# Altium schematic sheet Low_Voltage.SchDoc(Low_Voltage)
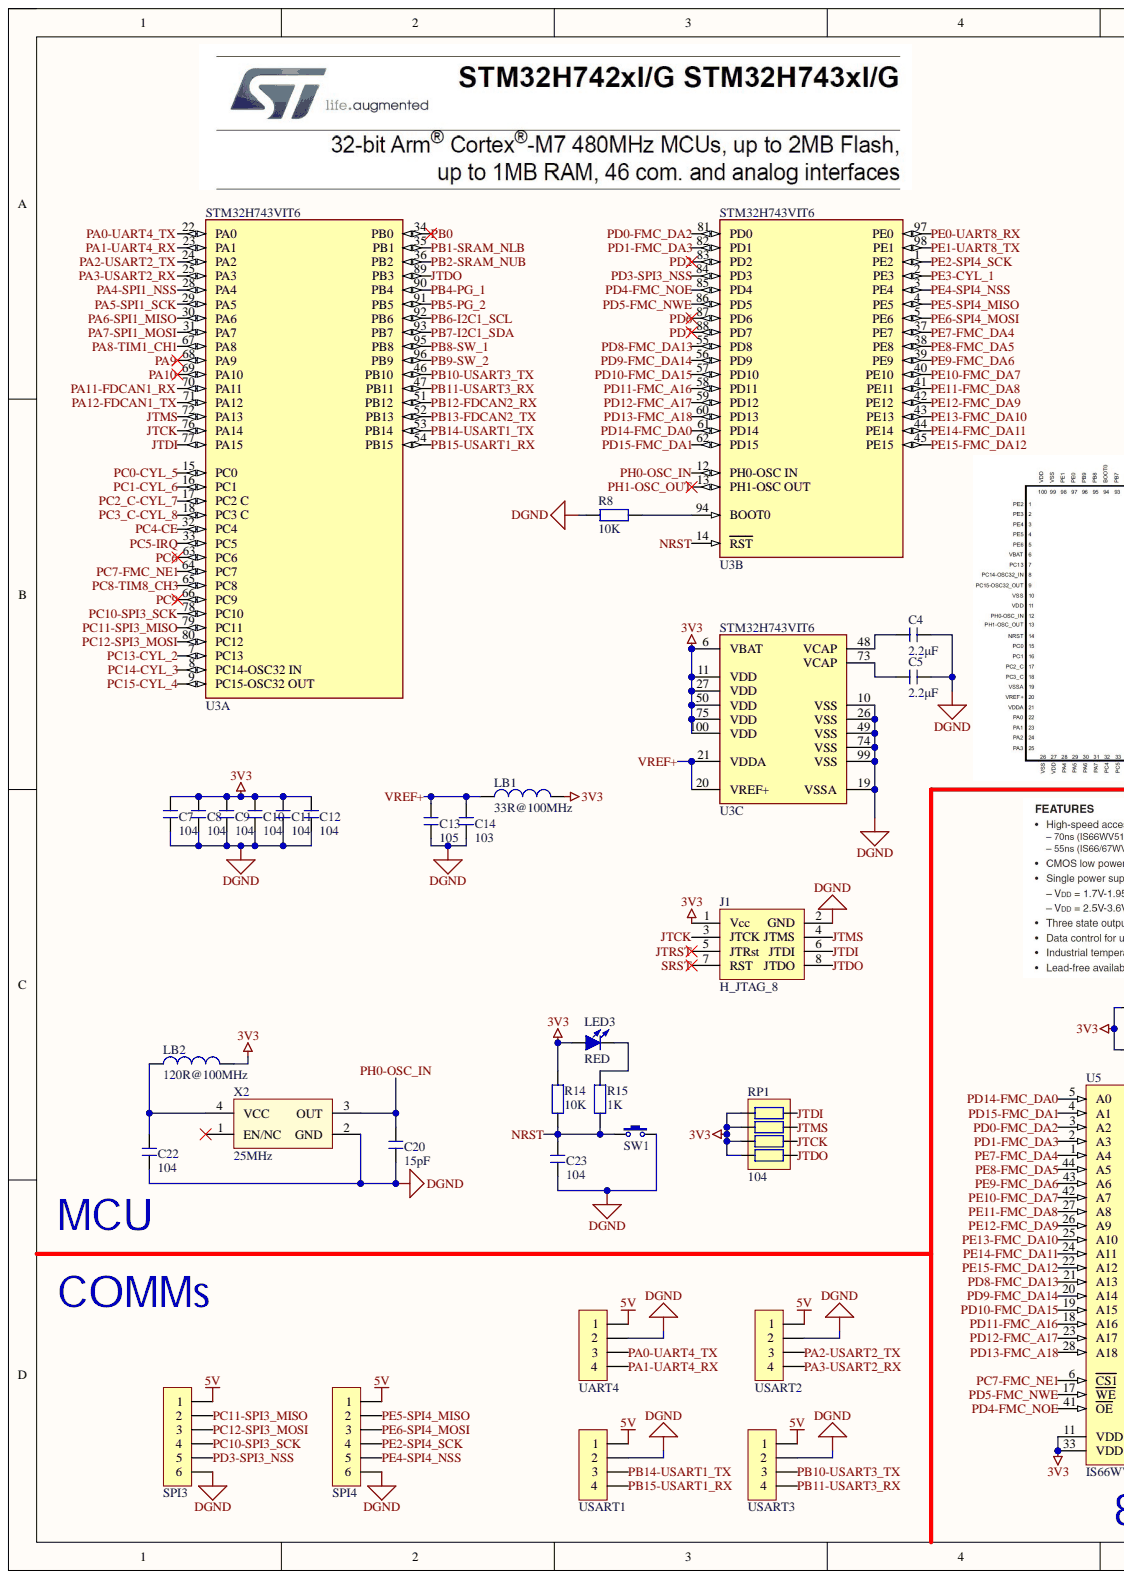
[diagram p0: Low_Voltage.SchDoc, left]
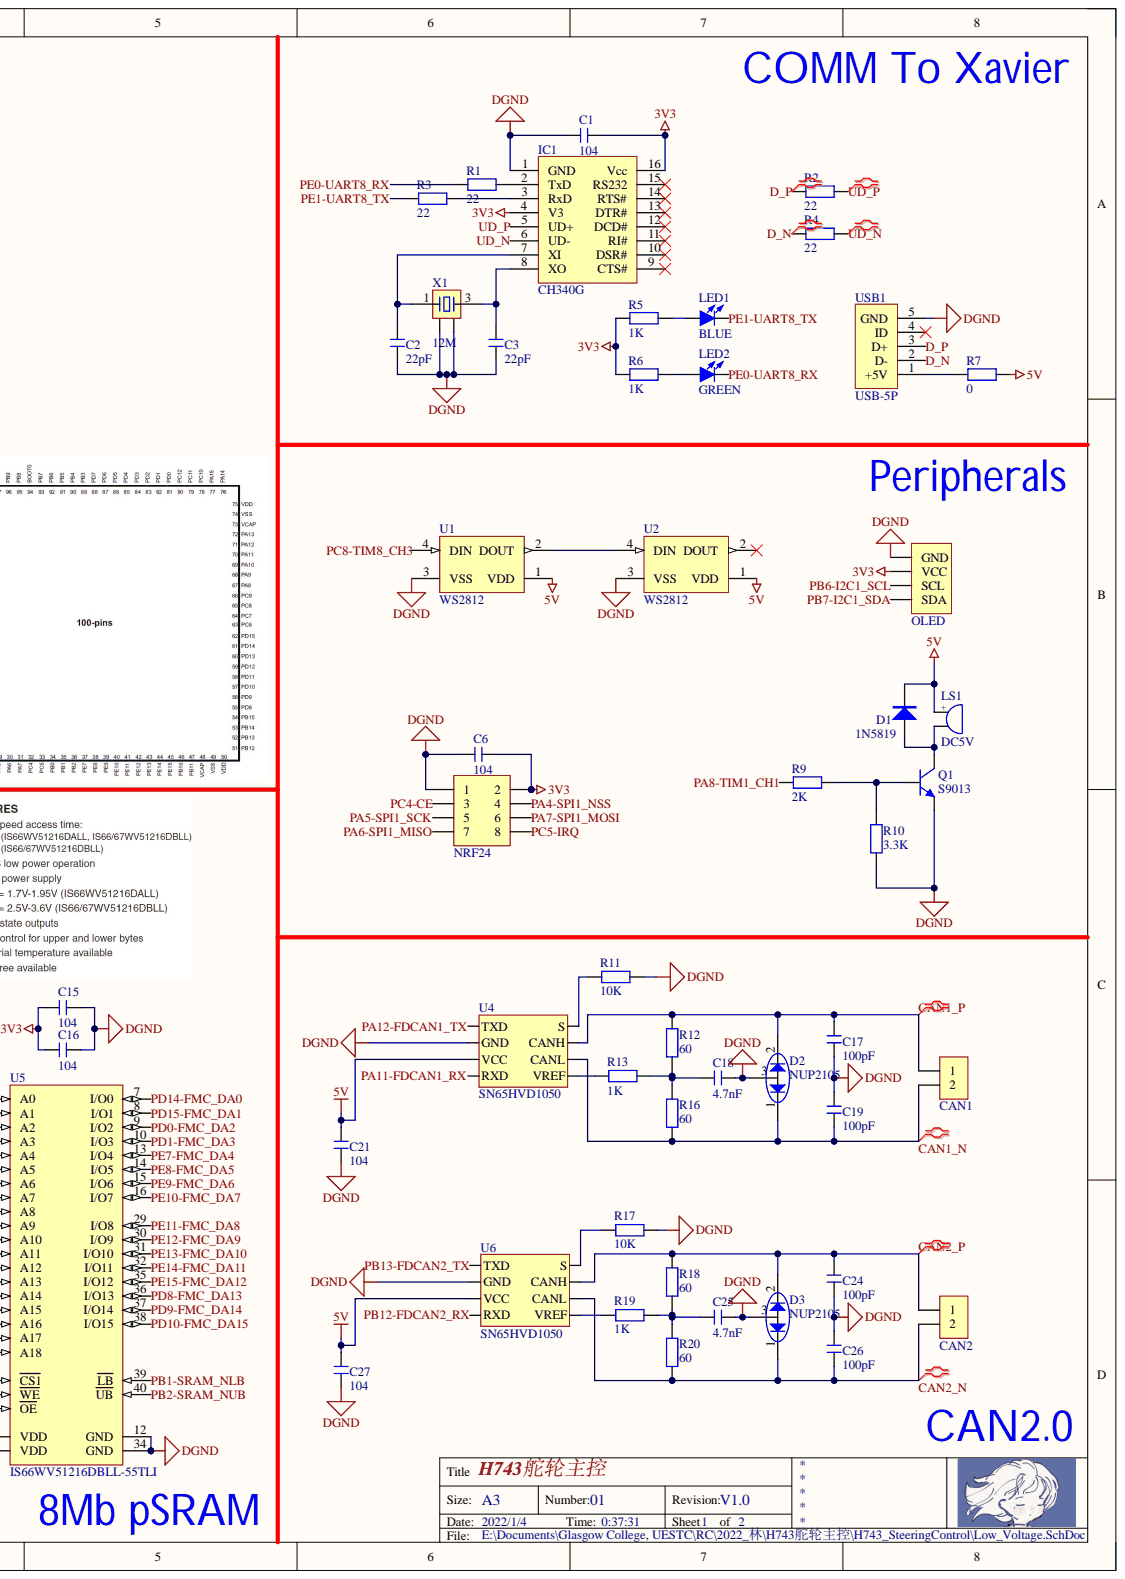
[diagram p1: Low_Voltage.SchDoc, right]

## Parts
C1  104  [C 0603_L]
C2  22pF  [C 0603_L]
C3  22pF  [C 0603_L]
C4  2.2μF  [C 0603_L]
C5  2.2μF  [C 0603_L]
C6  104  [C 0603_L]
C7  104  [C 0603_L]
C8  104  [C 0603_L]
C9  104  [C 0603_L]
C10  104  [C 0603_L]
C11  104  [C 0603_L]
C12  104  [C 0603_L]
C13  105  [C 0603_L]
C14  103  [C 0603_L]
C15  104  [C 0603_L]
C16  104  [C 0603_L]
C17  100pF  [C 0603_L]
C18  4.7nF  [C 0603_L]
C19  100pF  [C 0603_L]
C20  15pF  [C 0603_L]
C21  104  [C 0603_L]
C22  104  [C 0603_L]
C23  104  [C 0603_L]
C24  100pF  [C 0603_L]
C25  4.7nF  [C 0603_L]
C26  100pF  [C 0603_L]
C27  104  [C 0603_L]
CAN1  HDR-1X2  [XH2.54-LS-2P]  (value not drawn)
CAN2  HDR-1X2  [XH2.54-LS-2P]  (value not drawn)
D1  1N5819  [SOD-123]
D2  NUP2105  [SOT23-3L]
D3  NUP2105  [SOT23-3L]
IC1  CH340G  [SOP16_N]
J1  H_JTAG_8  [IDC2.54-LI-2x4P]
LB1  33R@100MHz  [FB 0603_L]
LB2  120R@100MHz  [FB 0603_L]
LED1  BLUE  [LED 0603B]
LED2  GREEN  [LED 0603G]
LED3  RED  [LED 0603R]
LS1  DC5V  [BEEP 6.5x12x6]
NRF24  E01C-ML01D  [HDR2.54-LI-2x4P]  (value not drawn)
OLED  HDR-1X4  [OLED_0.96寸-LI-4P]  (value not drawn)
Q1  S9013  [SOT23-3L]
R1  22  [R 0603_L]
R2  22  [R 0603_L]
R3  22  [R 0603_L]
R4  22  [R 0603_L]
R5  1K  [R 0603_L]
R6  1K  [R 0603_L]
R7  0  [R 0603_L]
R8  10K  [R 0603_L]
R9  2K  [R 0603_L]
R10  3.3K  [R 0603_L]
R11  10K  [R 0603_L]
R12  60  [R 0603_L]
R13  1K  [R 0603_L]
R14  10K  [R 0603_L]
R15  1K  [R 0603_L]
R16  60  [R 0603_L]
R17  10K  [R 0603_L]
R18  60  [R 0603_L]
R19  1K  [R 0603_L]
R20  60  [R 0603_L]
RP1  104  [RCA-8P4R-0603]
SPI3  HDR-1X6  [XH2.54-LS-6P]  (value not drawn)
SPI4  HDR-1X6  [XH2.54-LS-6P]  (value not drawn)
SW1  6x6x5  [TS 2PIN 6x6x4.8]  (value not drawn)
U1  WS2812  [WS2812B_B]
U2  WS2812  [WS2812B_B]
U3  STM32H743VIT6  [LQFP100 14x14_N]  (parts A,B,C)
U4  SN65HVD1050  [SOP8_L]
U5  IS66WV51216DBLL-55TLI  [ISSI-TSOP-2-44_N]
U6  SN65HVD1050  [SOP8_L]
UART4  HDR-1X4  [XH2.54-LS-4P]  (value not drawn)
USART1  HDR-1X4  [XH2.54-LS-4P]  (value not drawn)
USART2  HDR-1X4  [XH2.54-LS-4P]  (value not drawn)
USART3  HDR-1X4  [XH2.54-LS-4P]  (value not drawn)
USB1  USB-5P  [USB-MICRO_C]
X1  12M  [OSC 3225-4P]
X2  25MHz  [OSC 3225-4P]

## Nets
3V3: C1.1 C6.1 C7.2 C8.2 C9.2 C10.2 C11.2 C12.2 C15.2 C16.2 IC1.4(V3) IC1.16(Vcc) J1.1(Vcc) LB1.2 LB2.2 LED3.1 NRF24.2 OLED.2(VCC) R5.1 R6.1 R14.2 RP1.5 RP1.6 RP1.7 RP1.8 U3.6(VBAT) U3.11(VDD) U3.27(VDD) U3.50(VDD) U3.75(VDD) U3.100(VDD) U5.11(VDD) U5.33(VDD)
5V: C21.2 C27.2 D1.2 LS1.1 R7.2 SPI3.1 SPI4.1 U1.1(VDD) U2.1(VDD) U4.3(VCC) U6.3(VCC) UART4.1 USART1.1 USART2.1 USART3.1
DGND: C1.2 C2.1 C3.1 C4.2 C5.2 C6.2 C7.1 C8.1 C9.1 C10.1 C11.1 C12.1 C13.1 C14.1 C15.1 C16.1 C17.1 C18.2 C19.2 C20.1 C21.1 C22.1 C23.1 C24.1 C25.2 C26.2 C27.1 D2.3 D3.3 IC1.1(GND) J1.2(GND) NRF24.1 OLED.1(GND) Q1.2 R8.1 R10.1 R11.2 R17.2 SPI3.6 SPI4.6 SW1.2 U1.3(VSS) U2.3(VSS) U3.10(VSS) U3.19(VSSA) U3.26(VSS) U3.49(VSS) U3.74(VSS) U3.99(VSS) U4.2(GND) U5.12(GND) U5.34(GND) U6.2(GND) UART4.2 USART1.2 USART2.2 USART3.2 USB1.5(GND) X1.2 X1.4 X2.2(GND)
CAN1_N: C19.1 CAN1.2 D2.1 R16.1 U4.6(CANL)
CAN1_P: C17.2 CAN1.1 D2.2 R12.2 U4.7(CANH)
CAN2_N: C26.1 CAN2.2 D3.1 R20.1 U6.6(CANL)
CAN2_P: C24.2 CAN2.1 D3.2 R18.2 U6.7(CANH)
D_N: R4.1 USB1.2(D-)
D_P: R2.1 USB1.3(D+)
JTCK: J1.3(JTCK) RP1.2 U3.76(PA14)
JTDI: J1.6(JTDI) RP1.4 U3.77(PA15)
JTDO: J1.8(JTDO) RP1.1 U3.89(PB3)
JTMS: J1.4(JTMS) RP1.3 U3.72(PA13)
JTRST: J1.5(JTRst)
NRST: C23.2 R14.1 R15.1 SW1.1 U3.14(RST)
PA0-UART4_TX: U3.22(PA0) UART4.3
PA1-UART4_RX: U3.23(PA1) UART4.4
PA2-USART2_TX: U3.24(PA2) USART2.3
PA3-USART2_RX: U3.25(PA3) USART2.4
PA4-SPI1_NSS: NRF24.4 U3.28(PA4)
PA5-SPI1_SCK: NRF24.5 U3.29(PA5)
PA6-SPI1_MISO: NRF24.7 U3.30(PA6)
PA7-SPI1_MOSI: NRF24.6 U3.31(PA7)
PA8-TIM1_CH1: R9.1 U3.67(PA8)
PA9: U3.68(PA9)
PA10: U3.69(PA10)
PA11-FDCAN1_RX: U3.70(PA11) U4.4(RXD)
PA12-FDCAN1_TX: U3.71(PA12) U4.1(TXD)
PB0: U3.34(PB0)
PB1-SRAM_NLB: U3.35(PB1) U5.39(LB)
PB2-SRAM_NUB: U3.36(PB2) U5.40(UB)
PB4-PG_1: U3.90(PB4)
PB5-PG_2: U3.91(PB5)
PB6-I2C1_SCL: OLED.3(SCL) U3.92(PB6)
PB7-I2C1_SDA: OLED.4(SDA) U3.93(PB7)
PB8-SW_1: U3.95(PB8)
PB9-SW_2: U3.96(PB9)
PB10-USART3_TX: U3.46(PB10) USART3.3
PB11-USART3_RX: U3.47(PB11) USART3.4
PB12-FDCAN2_RX: U3.51(PB12) U6.4(RXD)
PB13-FDCAN2_TX: U3.52(PB13) U6.1(TXD)
PB14-USART1_TX: U3.53(PB14) USART1.3
PB15-USART1_RX: U3.54(PB15) USART1.4
PC0-CYL_5: U3.15(PC0)
PC1-CYL_6: U3.16(PC1)
PC2_C-CYL_7: U3.17(PC2_C)
PC3_C-CYL_8: U3.18(PC3_C)
PC4-CE: NRF24.3 U3.32(PC4)
PC5-IRQ: NRF24.8 U3.33(PC5)
PC6: U3.63(PC6)
PC7-FMC_NE1: U3.64(PC7) U5.6(CS1)
PC8-TIM8_CH3: U1.4(DIN) U3.65(PC8)
PC9: U3.66(PC9)
PC10-SPI3_SCK: SPI3.4 U3.78(PC10)
PC11-SPI3_MISO: SPI3.2 U3.79(PC11)
PC12-SPI3_MOSI: SPI3.3 U3.80(PC12)
PC13-CYL_2: U3.7(PC13)
PC14-CYL_3: U3.8(PC14-OSC32_IN)
PC15-CYL_4: U3.9(PC15-OSC32_OUT)
PD0-FMC_DA2: U3.81(PD0) U5.3(A2) U5.9(I/O2)
PD1-FMC_DA3: U3.82(PD1) U5.2(A3) U5.10(I/O3)
PD2: U3.83(PD2)
PD3-SPI3_NSS: SPI3.5 U3.84(PD3)
PD4-FMC_NOE: U3.85(PD4) U5.41(OE)
PD5-FMC_NWE: U3.86(PD5) U5.17(WE)
PD6: U3.87(PD6)
PD7: U3.88(PD7)
PD8-FMC_DA13: U3.55(PD8) U5.21(A13) U5.36(I/O13)
PD9-FMC_DA14: U3.56(PD9) U5.20(A14) U5.37(I/O14)
PD10-FMC_DA15: U3.57(PD10) U5.19(A15) U5.38(I/O15)
PD11-FMC_A16: U3.58(PD11) U5.18(A16)
PD12-FMC_A17: U3.59(PD12) U5.23(A17)
PD13-FMC_A18: U3.60(PD13) U5.28(A18)
PD14-FMC_DA0: U3.61(PD14) U5.5(A0) U5.7(I/O0)
PD15-FMC_DA1: U3.62(PD15) U5.4(A1) U5.8(I/O1)
PE0-UART8_RX: LED2.2 R1.1 U3.97(PE0)
PE1-UART8_TX: LED1.2 R3.1 U3.98(PE1)
PE2-SPI4_SCK: SPI4.4 U3.1(PE2)
PE3-CYL_1: U3.2(PE3)
PE4-SPI4_NSS: SPI4.5 U3.3(PE4)
PE5-SPI4_MISO: SPI4.2 U3.4(PE5)
PE6-SPI4_MOSI: SPI4.3 U3.5(PE6)
PE7-FMC_DA4: U3.37(PE7) U5.1(A4) U5.13(I/O4)
PE8-FMC_DA5: U3.38(PE8) U5.14(I/O5) U5.44(A5)
PE9-FMC_DA6: U3.39(PE9) U5.15(I/O6) U5.43(A6)
PE10-FMC_DA7: U3.40(PE10) U5.16(I/O7) U5.42(A7)
PE11-FMC_DA8: U3.41(PE11) U5.27(A8) U5.29(I/O8)
PE12-FMC_DA9: U3.42(PE12) U5.26(A9) U5.30(I/O9)
PE13-FMC_DA10: U3.43(PE13) U5.25(A10) U5.31(I/O10)
PE14-FMC_DA11: U3.44(PE14) U5.24(A11) U5.32(I/O11)
PE15-FMC_DA12: U3.45(PE15) U5.22(A12) U5.35(I/O12)
PH0-OSC_IN: C20.2 U3.12(PH0-OSC_IN) X2.3(OUT)
PH1-OSC_OUT: U3.13(PH1-OSC_OUT)
SRST: J1.7(RST)
UD_N: IC1.6(UD-) R4.2
UD_P: IC1.5(UD+) R2.2
VREF+: C13.2 C14.2 LB1.1 U3.20(VREF+) U3.21(VDDA)
NetC2_2: C2.2 IC1.7(XI) X1.1
NetC3_2: C3.2 IC1.8(XO) X1.3
NetC4_1: C4.1 U3.48(VCAP)
NetC5_1: C5.1 U3.73(VCAP)
NetC18_1: C18.1 R12.1 R13.1 R16.2
NetC22_2: C22.2 LB2.1 X2.4(VCC)
NetC25_1: C25.1 R18.1 R19.1 R20.2
NetD1_1: D1.1 LS1.2 Q1.3
NetIC1_2: IC1.2(TxD) R1.2
NetIC1_3: IC1.3(RxD) R3.2
NetLED1_1: LED1.1 R5.2
NetLED2_1: LED2.1 R6.2
NetLED3_2: LED3.2 R15.2
NetQ1_1: Q1.1 R9.2 R10.2
NetR7_1: R7.1 USB1.1(+5V)
NetR8_2: R8.2 U3.94(BOOT0)
NetR11_1: R11.1 U4.8(S)
NetR13_2: R13.2 U4.5(VREF)
NetR17_1: R17.1 U6.8(S)
NetR19_2: R19.2 U6.5(VREF)
NetU1_2: U1.2(DOUT) U2.4(DIN)

Not connected: IC1 9(CTS#) 10(DSR#) 11(RI#) 12(DCD#) 13(DTR#) 14(RTS#) 15(RS232); U2 2(DOUT); USB1 4(ID); X2 1(EN/NC)

## Library data of the parts
C1-C27 = C: 无极性贴片电容; 材质: X7R; BOM名称: 无极性贴片电容; Component Kind: Standard; Library Name: 3.1   - 电阻-电容-电感.SchLib
CAN1 CAN2 = Header 2: 2P接插件; BOM名称: 2P接插件; Component Kind: Standard; Library Name: 4.6   - 接插件-脚距2.54.SchLib
D1 = 1N5819: 肖特基二极管; 属性: 40V/2A; BOM名称: 肖特基二极管; Component Kind: Standard; Library Name: 8.1   - 二极管-整流桥.SchLib
D2 D3 = BAT54A: 表贴肖特基二极管; BOM名称: 肖特基二极管; 属性: 30V/0.2A; Component Kind: Standard; Library Name: 8.1   - 二极管-整流桥.SchLib
IC1 = CH340G: 串口转USB; BOM名称: 串口转USB; Component Kind: Standard; Library Name: 1.1   - IC芯片.SchLib
J1 = H_JTAG_8: 8脚JTAG下载接口; Component Kind: Standard; Library Name: 接插件.SCHLIB
LB1 LB2 = LB: 磁珠; BOM名称: 磁珠; Component Kind: Standard; Library Name: 3.1   - 电阻-电容-电感.SchLib
LED1-LED3 = LED-SMD: 贴片LED; BOM名称: 贴片LED; Component Kind: Standard; Library Name: 9.1   - LED.SchLib
LS1 = BEEP: 蜂鸣器; BOM名称: 蜂鸣器; Component Kind: Standard; Library Name: 15.1 - 未分类器件.SchLib
NRF24 = Header 4X2: 4*2P接插件; BOM名称: 4*2P接插件; Component Kind: Standard; Library Name: 4.6   - 接插件-脚距2.54.SchLib
OLED = OLED_I2C_128*64: OLED-4P接插件; BOM名称: 4P接插件; Component Kind: Standard; Library Name: KEY.IntLib
Q1 = 9013-SMD: 低频放大-NPN型; 属性: 50V?0.5A?0.625W; BOM名称: 低频放大-NPN型; Component Kind: Standard; Library Name: 6.2   - 三极管.SchLib
R1-R20 = R: 贴片电阻; BOM名称: 贴片电阻; Component Kind: Standard; Library Name: 3.1   - 电阻-电容-电感.SchLib
RP1 = R-8P4R: 贴片网络排阻; BOM名称: 贴片网络排阻; Component Kind: Standard; Library Name: 3.1   - 电阻-电容-电感.SchLib
SPI3 SPI4 = Header 6: 6P接插件; BOM名称: 6P接插件; Component Kind: Standard; Library Name: 4.6   - 接插件-脚距2.54.SchLib
SW1 = TSW SMD-2Pin-6x6: 2脚贴片6x6无声硅胶轻触开关; BOM名称: 2脚轻触开关; Component Kind: Standard; Library Name: KEY.IntLib
U1 U2 = WS2812: Intelligent Control LED Integrated Light Source, 3.5 to 5.3 V, -25 to 80 degC, 4-Pin SMD, RoHS, Bulk; PartNumber: WS2812B; Case\Package: Radial; Current Rating: 240 mA; Shielding: Unshielded; Tolerance: 10%; Component Kind: Standard; ComponentLink1Description: Manufacturer URL; ComponentLink1URL: https://www.adafruit.com/; ComponentLink2Description: Datasheet; ComponentLink2URL: https://cdn-shop.adafruit.com/datasheets/WS2812B.pdf; DatasheetVersion: May-13; Height: 12.2 mm; Lead Pitch: 5 mm; Length: 12.2 mm; Library Name: KEY.IntLib; Max Operating Temperature: 125 degC; Min Operating Temperature: -55 degC; Mount: Through Hole; Package Quantity: 200; PackageDescription: 4-Pin Surface Mount Device, Body 5 x 5 mm, Pitch 3.3 mm; PackageReference: WS2812B; Packaging: Bulk; Pins: 2; Radiation Hardening: No; REACH SVHC: No SVHC; RoHSCompliant: true
U3 = STM32H743VIT6: MCU 32-Bit STM32 ARM Cortex M7 RISC 2MB Flash 1.8V/2.5V/3.3V 100-Pin LQFP Tray; Component Kind: Standard; Library Name: 电赛IC.IntLib
U4 U6 = SN65HVD1050: EMC Optimized CAN Bus Transceiver; Component Kind: Standard; Library Name: 高级IC.SchLib
U5 = IS66WV51216DBLL-55TLI: 8 M Low Voltage, Ultra Low Power Pseudo CMOS SRAM, 512 K x 16, -40 to 85 degC, 44-Pin SOP (TSOP-2-44), Pb-Free; Manufacturer: Integrated Silicon Solution Inc (ISSI); Density: 8M; Packing: Tray / Tube; Speed (ns): 55; Component Kind: Standard; ComponentLink1Description: Manufacturer URL; ComponentLink1URL: http://www.issi.com/US/index.shtml; ComponentLink2Description: Datasheet; ComponentLink2URL: http://www.issi.com/WW/pdf/66WV51216DALL-DBLL.pdf; ComponentLink3Description: Package Specification; ComponentLink3URL: http://www.issi.com/WW/pdf/61-64WV6416EEBLL.pdf; DatasheetVersion: Rev. A; Mounting Technology: Surface Mount; Organization: 512K x 16; PackageDescription: 44-Pin 400 mil TSOP-2, Body 18.41 x 10.16 mm, Pitch 0.8 mm; PackageReference: TSOP-2-44; PackageVersion: Rev. F, 06/2008; PartNumber: IS66WV51216DBLL-55TLI; RoHS: Pb-Free; Library Name: 高级IC.IntLib
UART4 USART1-USART3 = Header 4: 4P接插件; BOM名称: 4P接插件; Component Kind: Standard; Library Name: 4.6   - 接插件-脚距2.54.SchLib
USB1 = USB-5P: 微型USB母座; BOM名称: 微型USB母座; Component Kind: Standard; Library Name: 4.1   - 接口类.SchLib
X1 = XTAL-4P: 4脚无源晶振; 负载电容: CL20pF; BOM名称: 4脚无源晶振; Component Kind: Standard; Library Name: 10.1 - 保险丝-晶振.SchLib
X2 = XTAL-ACT-4P: 4脚有源晶振; BOM名称: 4脚有源晶振; Component Kind: Standard; Library Name: 10.1 - 保险丝-晶振.SchLib
